# Altium schematic sheet Sensors.SchDoc(Sensors)
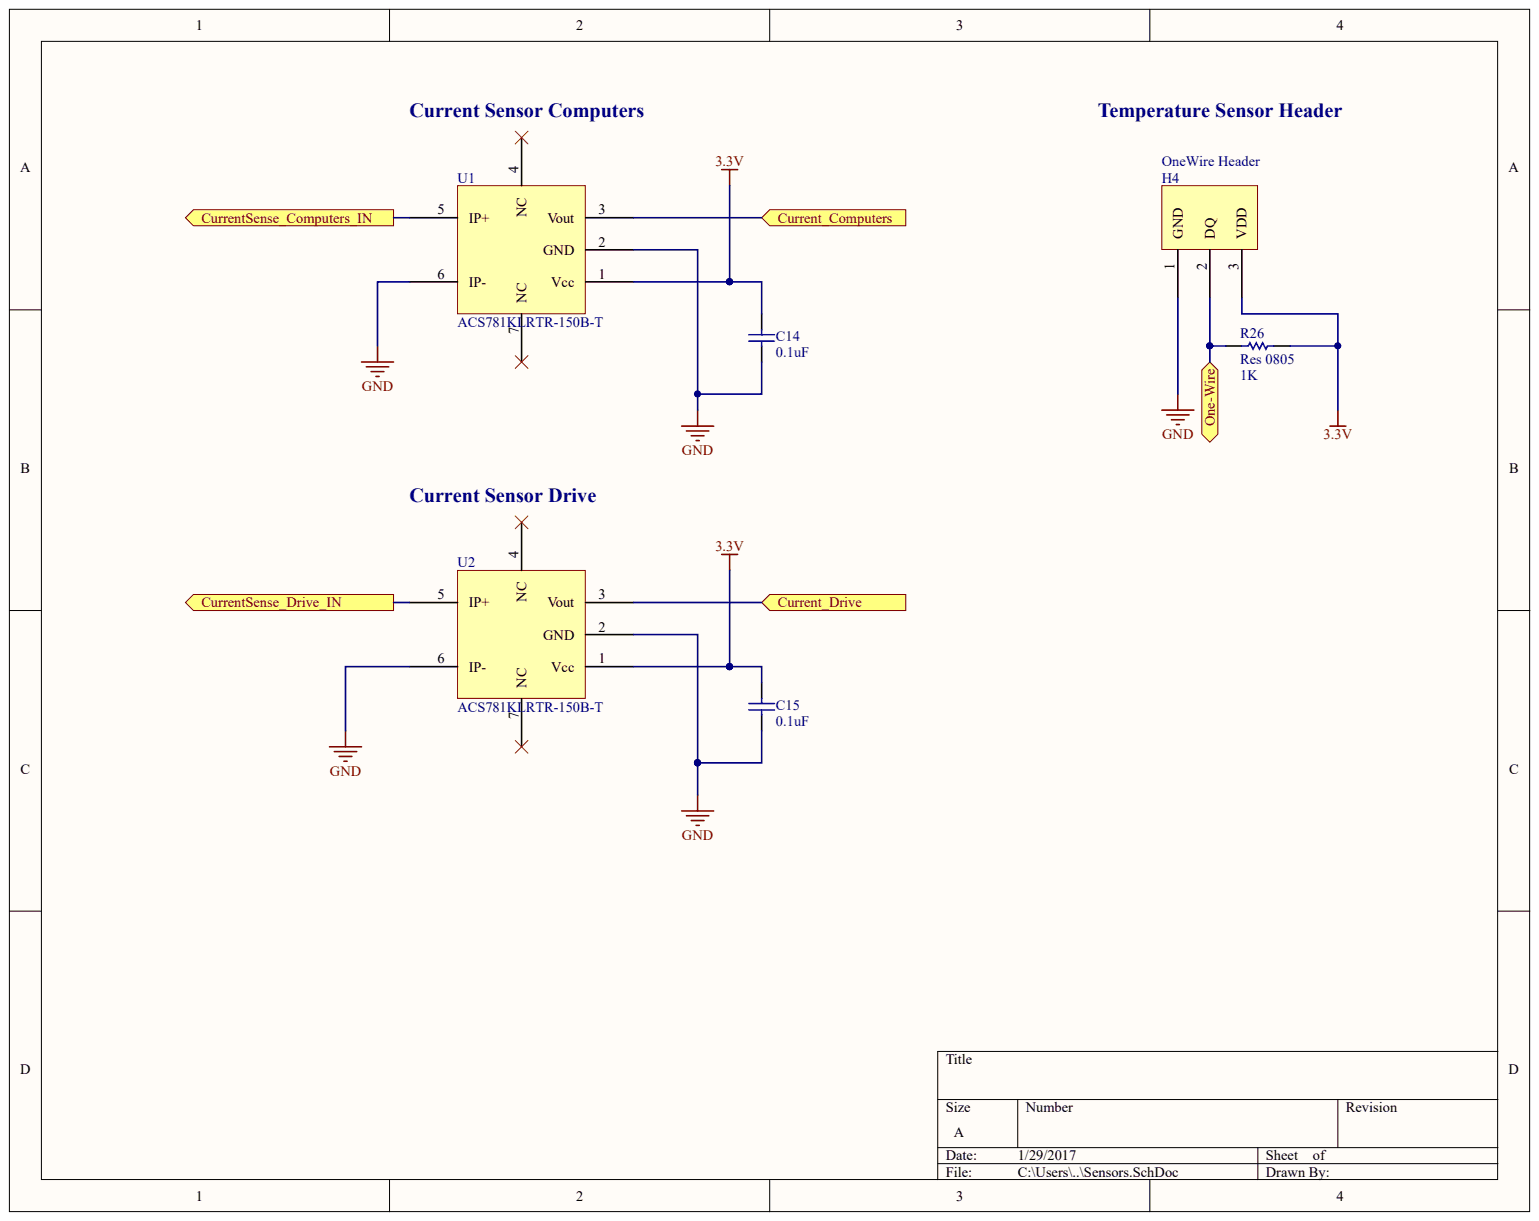
[diagram p0: Sensors.SchDoc]

## Parts
C14  value 0.1uF  (hidden comment: Cap_0805)
C15  value 0.1uF  (hidden comment: Cap_0805)
H4  OneWire Header
R26  Res 0805  value 1K
U1  ACS781KLRTR-150B-T
U2  ACS781KLRTR-150B-T

## Ports
CURRENT_COMPUTERS, CURRENT_DRIVE, CURRENTSENSE_COMPUTERS_IN, CURRENTSENSE_DRIVE_IN, ONE-WIRE

## Nets
3.3V: C14.1 C15.1 H4.3 R26.2 U1.1(Vcc) U2.1(Vcc)
CURRENT_COMPUTERS: U1.3(Vout) ; port CURRENT_COMPUTERS
CURRENT_DRIVE: U2.3(Vout) ; port CURRENT_DRIVE
CURRENTSENSE_COMPUTERS_IN: U1.5(IP+) ; port CURRENTSENSE_COMPUTERS_IN
CURRENTSENSE_DRIVE_IN: U2.5(IP+) ; port CURRENTSENSE_DRIVE_IN
GND: C14.2 C15.2 H4.1 U1.2(GND) U1.6(IP-) U2.2(GND) U2.6(IP-)
ONE-WIRE: H4.2 R26.1 ; port ONE-WIRE

Not connected: U1 4(NC) 7(NC); U2 4(NC) 7(NC)
